# Altium schematic sheet Main.SchDoc
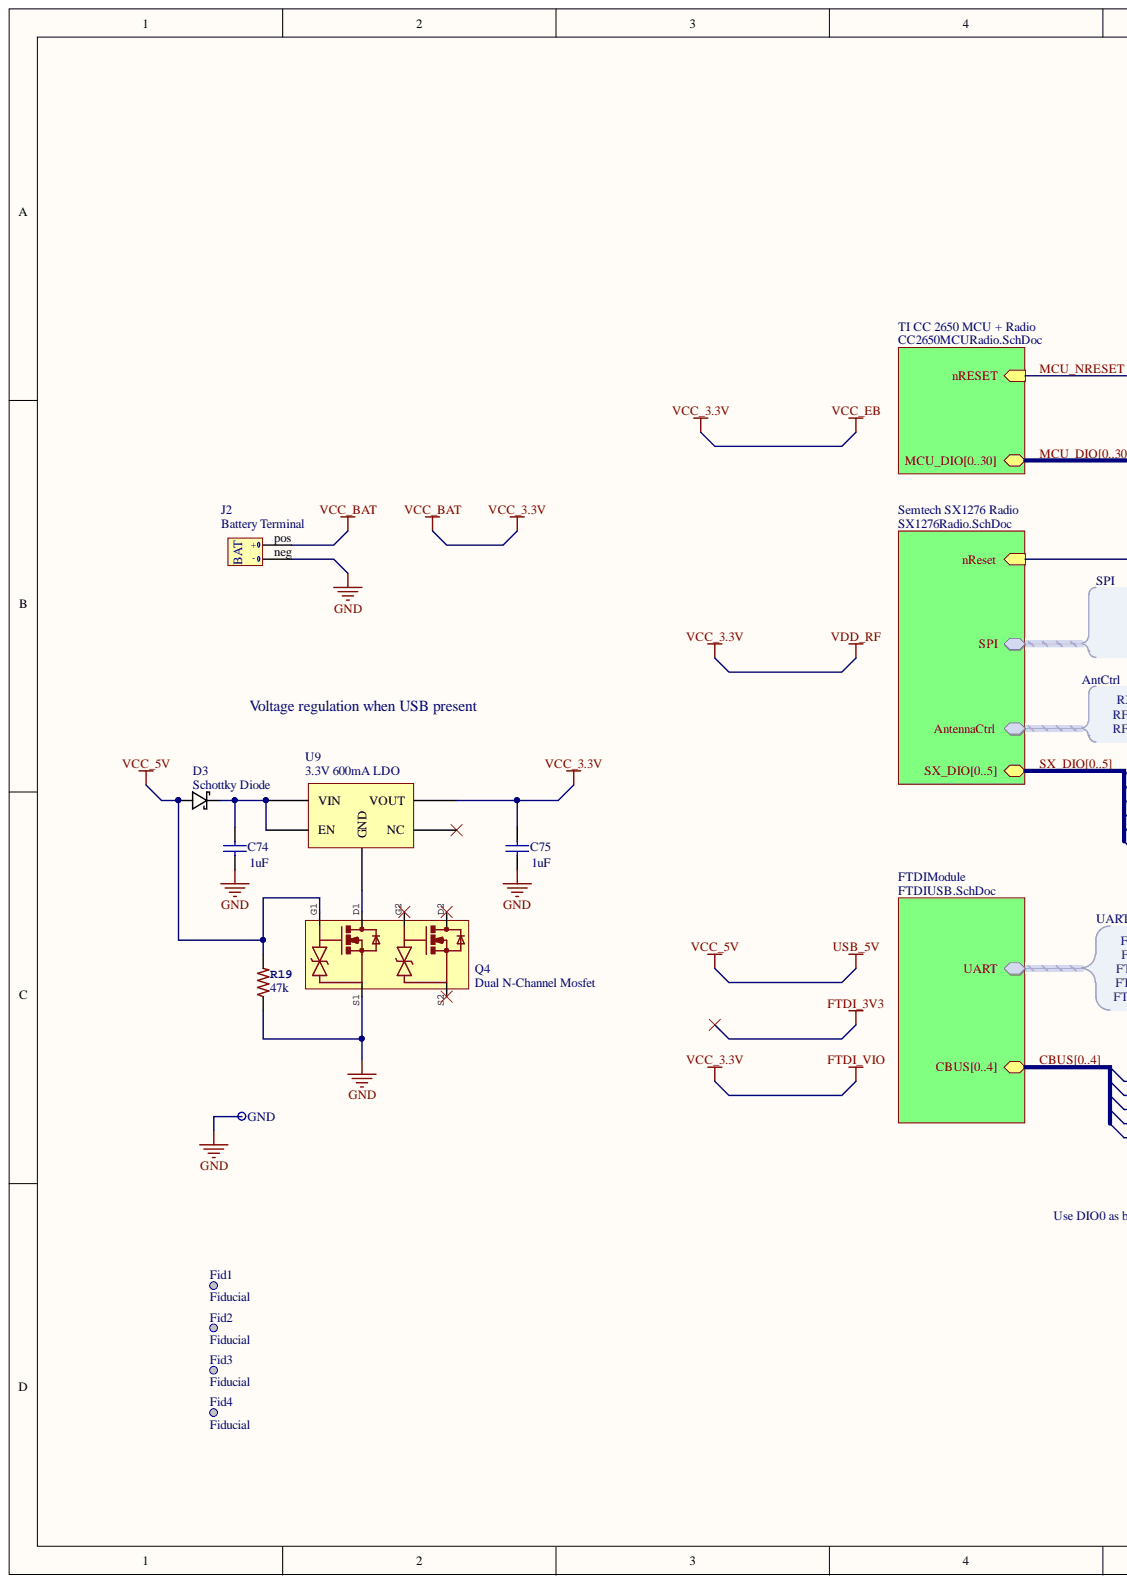
[diagram p0: Main.SchDoc, left]
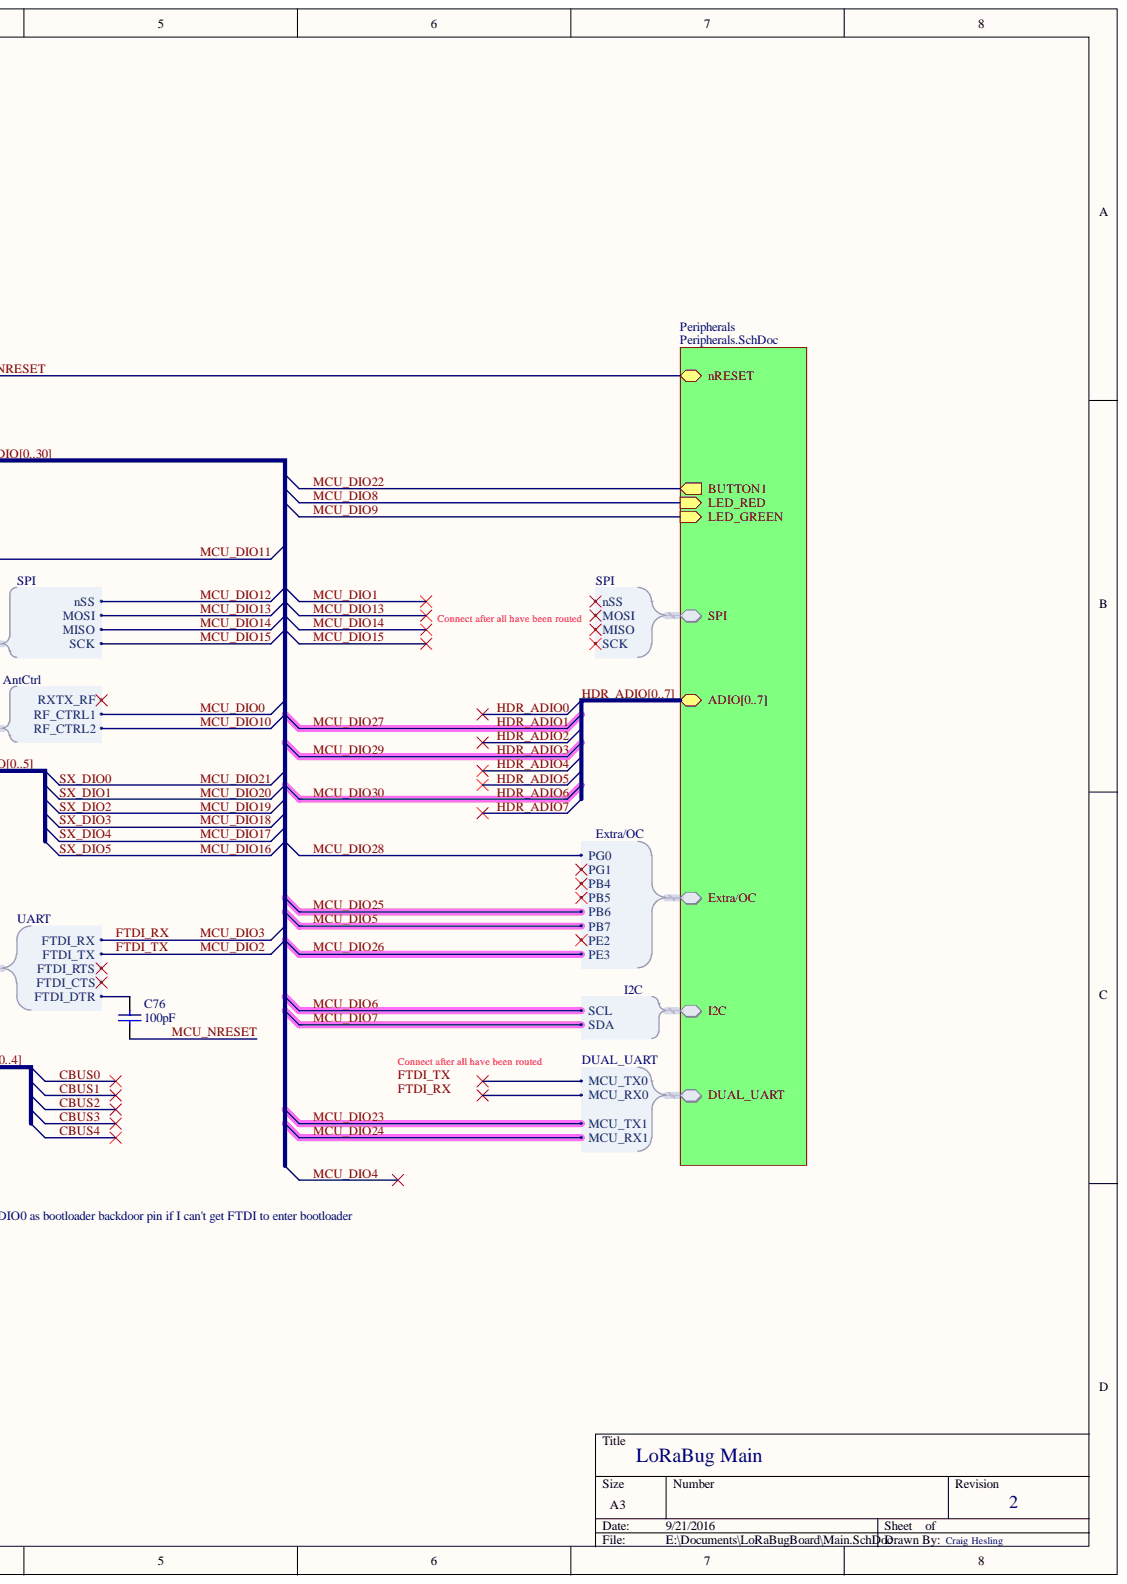
[diagram p1: Main.SchDoc, right]

## Parts
C74  value 1uF  [1608[0603]]  (hidden comment: Cap Semi)
C75  value 1uF  [1608[0603]]  (hidden comment: Cap Semi)
C76  value 100pF  [0402]  (hidden comment: Cap Semi)
D3  Schottky Diode  [DB2J20900L (high-density)]
Fid1  Fiducial  [Fiducial]
Fid2  Fiducial  [Fiducial]
Fid3  Fiducial  [Fiducial]
Fid4  Fiducial  [Fiducial]
GND  Test Pin  [pin_1mm]  (value not drawn)
J2  Battery Terminal  [Battery Terminal]
Q4  Dual N-Channel Mosfet  [FC6946010R (high-density)]
R19  value 47k  [0603-RES]  (hidden comment: RES-00818)
U9  3.3V 600mA LDO  [AP7365-SOT25 (high-density)]

## Nets
FTDI_VIO: C75.2 J2.pos U9.VOUT
GND: C74.1 C75.1 GND.1 J2.neg Q4.S1 R19.1
USB_5V: D3.2 Q4.G1 R19.2
MCU_NRESET: C76.1
NetC74_2: C74.2 D3.1 U9.EN U9.VIN
NetC76_2: C76.2
NetQ4_D1: Q4.D1 U9.GND
NetQ4_D2: Q4.D2
NetQ4_G2: Q4.G2
NetQ4_S2: Q4.S2
NetU9_NC: U9.NC
ANTENNACTRL.RXTX_RF:
CBUS0:  [also labelled CBUS]
CBUS1:  [also labelled CBUS]
CBUS2:  [also labelled CBUS]
CBUS3:  [also labelled CBUS]
CBUS4:  [also labelled CBUS]
DUAL_UART.MCU_RX0:
DUAL_UART.MCU_TX0:
EXTRA/OC.PB4:
EXTRA/OC.PB5:
EXTRA/OC.PE2:
EXTRA/OC.PG1:
FTDI_3V3:
HDR_ADIO0:  [also labelled HDR_ADIO]
HDR_ADIO1:  [also labelled HDR_ADIO]
HDR_ADIO2:  [also labelled HDR_ADIO]
HDR_ADIO3:  [also labelled HDR_ADIO]
HDR_ADIO4:  [also labelled HDR_ADIO]
HDR_ADIO5:  [also labelled HDR_ADIO]
HDR_ADIO6:  [also labelled HDR_ADIO]
HDR_ADIO7:  [also labelled HDR_ADIO]
MCU_DIO0:  [also labelled MCU_DIO]
MCU_DIO1:  [also labelled MCU_DIO]
MCU_DIO4:  [also labelled MCU_DIO]
MCU_DIO5:  [also labelled MCU_DIO]
MCU_DIO6:  [also labelled MCU_DIO]
MCU_DIO7:  [also labelled MCU_DIO]
MCU_DIO8:  [also labelled MCU_DIO]
MCU_DIO9:  [also labelled MCU_DIO]
MCU_DIO10:  [also labelled MCU_DIO]
MCU_DIO11:  [also labelled MCU_DIO]
MCU_DIO12:  [also labelled MCU_DIO]
MCU_DIO13:  [also labelled MCU_DIO]
MCU_DIO14:  [also labelled MCU_DIO]
MCU_DIO15:  [also labelled MCU_DIO]
MCU_DIO16:  [also labelled MCU_DIO]
MCU_DIO17:  [also labelled MCU_DIO]
MCU_DIO18:  [also labelled MCU_DIO]
MCU_DIO19:  [also labelled MCU_DIO]
MCU_DIO20:  [also labelled MCU_DIO]
MCU_DIO21:  [also labelled MCU_DIO]
MCU_DIO22:  [also labelled MCU_DIO]
MCU_DIO23:  [also labelled MCU_DIO]
MCU_DIO24:  [also labelled MCU_DIO]
MCU_DIO25:  [also labelled MCU_DIO]
MCU_DIO26:  [also labelled MCU_DIO]
MCU_DIO28:  [also labelled MCU_DIO]
SPI.MISO:
SPI.MOSI:
SPI.NSS:
SPI.SCK:
SX_DIO[0..5]:
UART.FTDI_CTS:
UART.FTDI_RTS:

## Library data of the parts
C74-C76 = Cap Semi: Capacitor (Semiconductor SIM Model); Component Kind: Standard; LatestRevisionDate: 29-May-2009; LatestRevisionNote: IPC-7351 Footprint Added.; Library Name: Miscellaneous Devices.IntLib; PackageDescription: Chip Capacitor; PackageReference: C1206; Published: 8-Jun-2000; Signal Integrity: Cap Semi; Simulation: CAP; Publisher: Altium Limited
D3 = DB2J20900L: Schottky Diode DB2J20900L; Component Kind: Standard; Supplier 1: Digi-Key; Supplier Part Number 1: DB2J20900LTR-ND; Library Name: ExtraComponents.SchLib
Fid1-Fid4 = Fiducial: Fiducial mark from Patrick's library; Component Kind: Standard; Library Name: ExtraComponents.SchLib
GND = test_pin: Component Kind: Standard; Description: Test Pin; LatestRevisionDate: 05-oct-2007  -  Semtech; Library Name: SX1276_e268v01a.SchLib
Q4 = FC6946010R: Panasonic Dual N-Channel Moseft FC6946010R; Component Kind: Standard; Supplier 1: Newark; Supplier 2: Digi-Key; Supplier Part Number 1: 63W2173; Supplier Part Number 2: FC6946010RCT-ND; Library Name: ExtraComponents.SchLib
R19 = 330OHM1/10W1%(0603): Component Kind: Standard; Library Name: SparkFun-Resistors.SCHLIB; PROD_ID: RES-00818
U9 = AP7365-33WG-7: AP7365-33WG-7 3.9V 600mA LDO; Component Kind: Standard; Supplier 1: Digi-Key; Supplier 2: Arrow; Supplier Part Number 1: AP7365-33WG-7DICT-ND; Supplier Part Number 2: 8jDkOUuQMN4bQRMK7daIL_aN6lyR31ZrRHAvlbe4_1s; Library Name: ExtraComponents.SchLib
J2 = Battery Terminal: Component Kind: Standard; Library Name: ExtraComponents.SchLib
